# Altium schematic sheet Hall_sensor.SchDoc
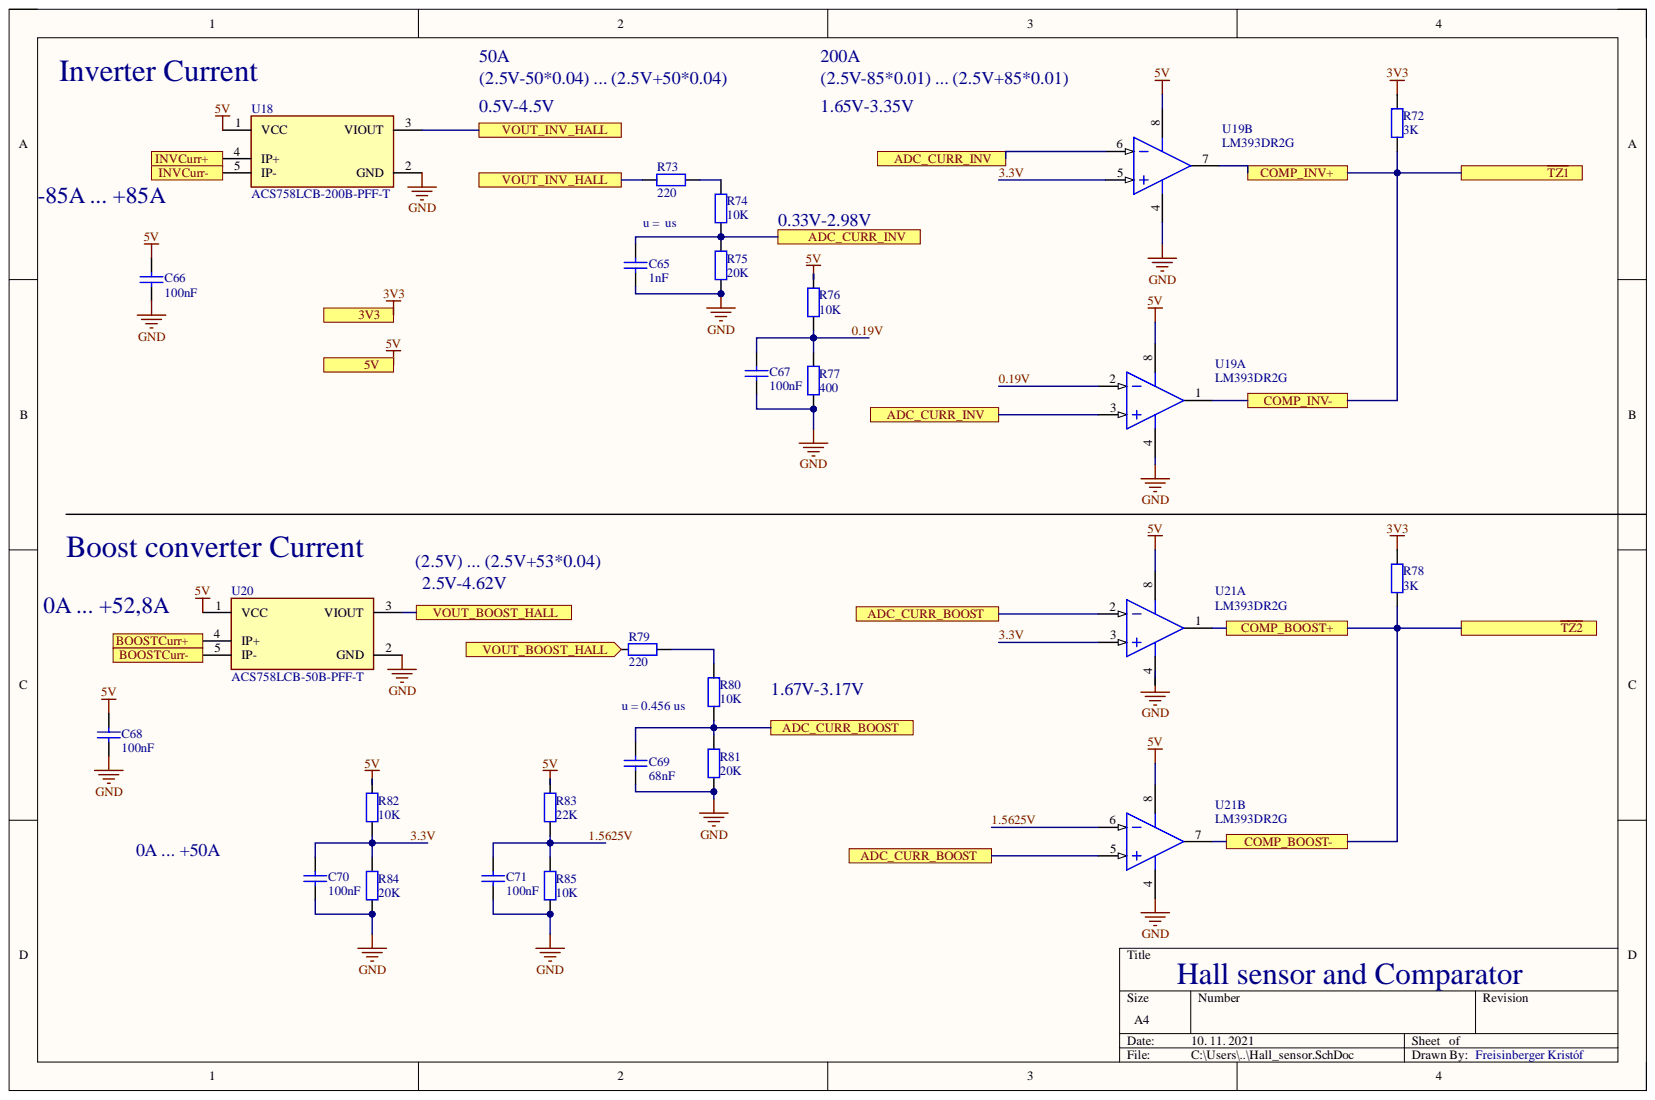
[diagram p0: Hall_sensor.SchDoc]

## Parts
C65  1nF  [FP_0805_CAP_085]
C66  100nF  [FP_0805_CAP_085]
C67  100nF  [FP_0805_CAP_085]
C68  100nF  [FP_0805_CAP_085]
C69  68nF  [FP_0805_CAP_085]
C70  100nF  [FP_0805_CAP_085]
C71  100nF  [FP_0805_CAP_085]
R72  3K  [FP_0603_RES]
R73  220  [FP_0603_RES]
R74  10K  [FP_0603_RES]
R75  20K  [FP_0603_RES]
R76  10K  [FP_0603_RES]
R77  400  [FP_0603_RES]
R78  3K  [FP_0603_RES]
R79  220  [FP_0603_RES]
R80  10K  [FP_0603_RES]
R81  20K  [FP_0603_RES]
R82  10K  [FP_0603_RES]
R83  22K  [FP_0603_RES]
R84  20K  [FP_0603_RES]
R85  10K  [FP_0603_RES]
U18  ACS758LCB-200B-PFF-T  Operating Supply Voltage: 5V  [FP-CB-5-PFF-MFG]
U19  LM393DR2G  [ONSC-SOIC-8-751-07_V]  (parts A,B)
U20  ACS758LCB-50B-PFF-T  Operating Supply Voltage: 5V  [FP-CB-5-PFF-MFG]
U21  LM393DR2G  [ONSC-SOIC-8-751-07_V]  (parts A,B)

## Ports
3V3, 5V, ADC_CURR_BOOST, ADC_CURR_INV, BOOSTCurr+, BOOSTCurr-, COMP_BOOST+, COMP_BOOST-, COMP_INV+, COMP_INV-, INVCurr+, INVCurr-, VOUT_BOOST_HALL, VOUT_INV_HALL, ~{TZ1}, ~{TZ2}

## Nets
GND: C65.2 C66.2 C67.2 C68.2 C69.2 C70.2 C71.2 R75.1 R77.2 R81.2 R84.2 R85.2 U18.2(GND) U19.4 U20.2(GND) U21.4
0.19V: C67.1 R76.2 R77.1 U19.2
1.5625V: C71.1 R83.2 R85.1 U21.6
3.3V: C70.1 R82.2 R84.1 U19.5 U21.3
3V3: R72.2 R78.2 ; port 3V3
5V: C66.1 C68.1 R76.1 R82.1 R83.1 U18.1(VCC) U19.8 U20.1(VCC) U21.8 ; port 5V
ADC_CURR_BOOST: C69.1 R80.2 R81.1 U21.2 U21.5 ; port ADC_CURR_BOOST
ADC_CURR_INV: C65.1 R74.2 R75.2 U19.3 U19.6 ; port ADC_CURR_INV
~{TZ1}: R72.1 U19.1 U19.7 ; port ~{TZ1}
~{TZ2}: R78.1 U21.1 U21.7 ; port ~{TZ2}
VOUT_BOOST_HALL: U20.3(VIOUT) ; port VOUT_BOOST_HALL
VOUT_INV_HALL: R73.1 U18.3(VIOUT) ; port VOUT_INV_HALL
NetR73_2: R73.2 R74.1
NetR79_1: R79.1 R80.1
NetU18_4: U18.4(IP+)
NetU18_5: U18.5(IP-)
NetU20_4: U20.4(IP+)
NetU20_5: U20.5(IP-)

Not connected: R79 2

## Library data of the parts
C65-C71 = CAP: Capacitor; Component Kind: Standard; Library Name: DCDC_Converter_4_Channel.SchLib
  C65: Value: 1nF
  C66-C68 C70 C71: Value: 100nF
  C69: Value: 68nF
R72-R85 = RES: Resistor; Component Kind: Standard; Library Name: DCDC_Converter_4_Channel.SchLib
  R72 R78: Value: 3K
  R73 R79: Value: 220
  R74 R76 R80 R82 R85: Value: 10K
  R75 R81 R84: Value: 20K
  R77: Value: 400
  R83: Value: 22K
U18 U20 = CMP-82923-000204-2: SENSOR CURRENT HALL 100A AC/DC; Accuracy: 2.4%; Bandwidth: 120kHz; Linearity: 1.25%; Max Supply Current: 13.5mA; Max Supply Voltage: 5.5V; Min Supply Voltage: 3V; Number of Channels: 1; Output Current: 1mA; Polarity: Bidirectional; Quiescent Current: 10mA; Response Time: 4us; Sensitivity: 20mV/A; Component Kind: Standard; Current Sensing: 100A; Frequency: 120kHz; Height: 7mm; Length: 10mm; Library Name: Altium Content Vault; Max Operating Temperature: 150°C; Min Operating Temperature: -40°C; Mount: Through Hole; Number of Pins: 5; Package Quantity: 34; Packaging: Rail/Tube; Radiation Hardening: No; REACH SVHC: No SVHC; RoHS Compliant: Yes; Series: -; Width: 13mm
U19 U21 = CMP-1305-00048-1: Low Offset Voltage Dual Comparators, 2 to 36 V, 0 to 70 degC, 8-Pin SOIC, Pb-Free, Tape and Reel; Manufacturer: On Semiconductor; ICC Typ (mA): 0.4; IO Typ (mA): 16; VCC Max (V): 36; VCC Min (V): 2; Component Kind: Standard; ComponentLink1Description: Manufacturer URL; ComponentLink1URL: http://www.onsemi.com/; ComponentLink2Description: Datasheet; ComponentLink2URL: http://www.onsemi.com/pub_link/Collateral/LM393-D.PDF; ComponentLink3Description: Package Specification; ComponentLink3URL: http://www.onsemi.com/pub_link/Collateral/751-07.PDF; DatasheetVersion: Rev. 25; Library Name: Altium Content Vault; Mounting Technology: Surface Mount; PackageDescription: 8-Pin Small Outline Integrated Circuit, Body 4.9 x 3.9 mm, Pitch 1.27 mm; PackageReference: SOIC-8-751-07; PackageVersion: Rev. AK, 02/2011; Packing: Tape and Reel; PartNumber: LM393DR2G; RoHS: TRUE
